# Altium schematic sheet sensors.SchDoc(sensors)
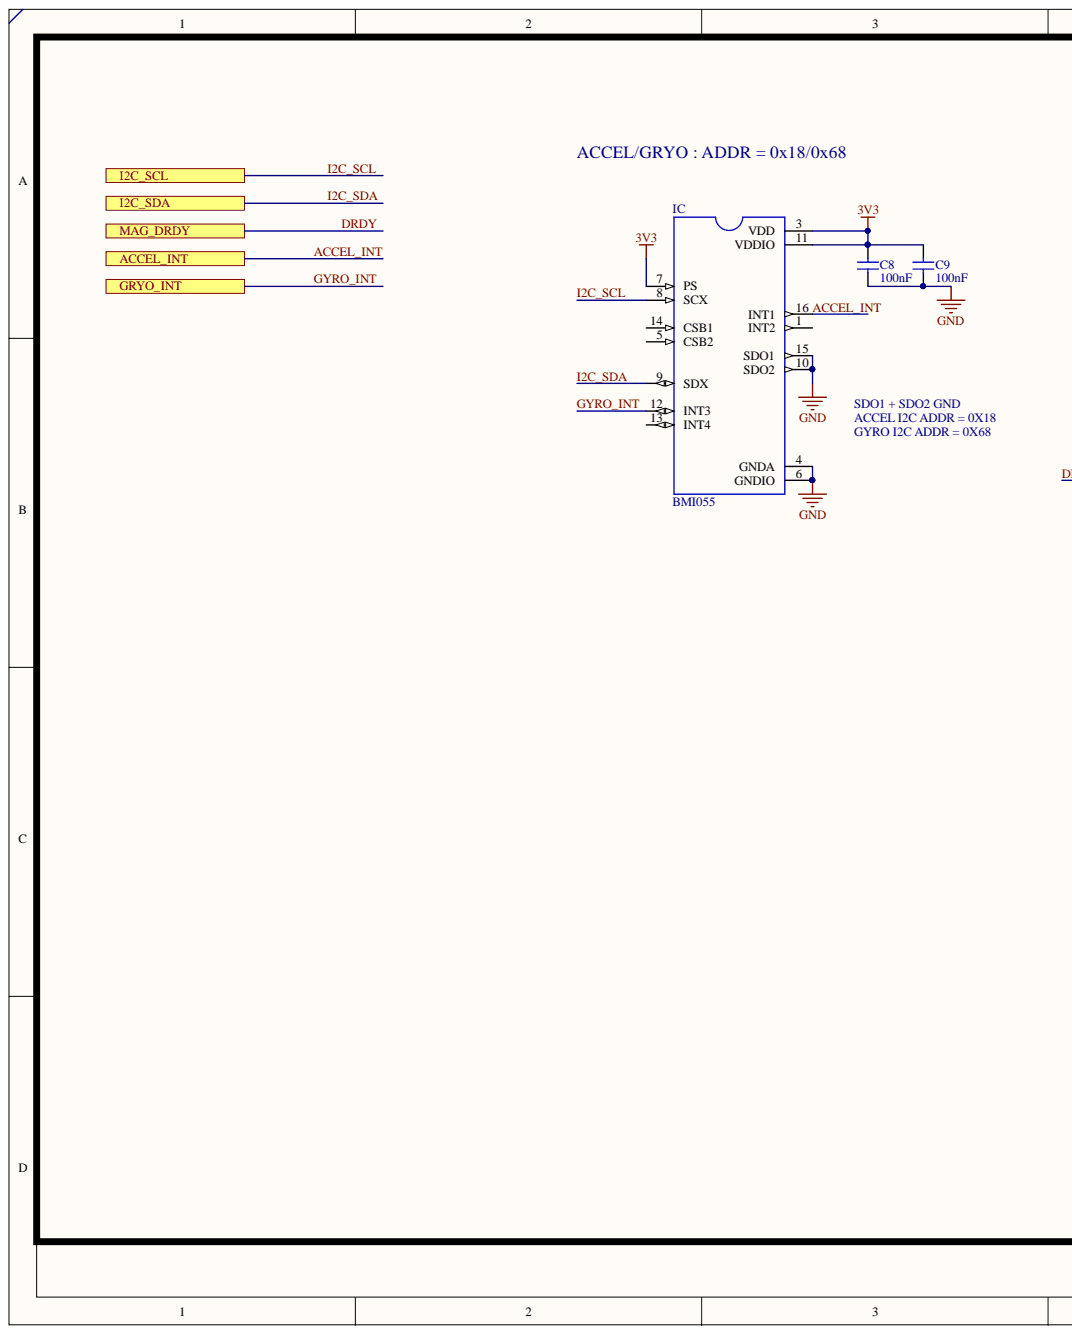
[diagram p0: sensors.SchDoc, left]
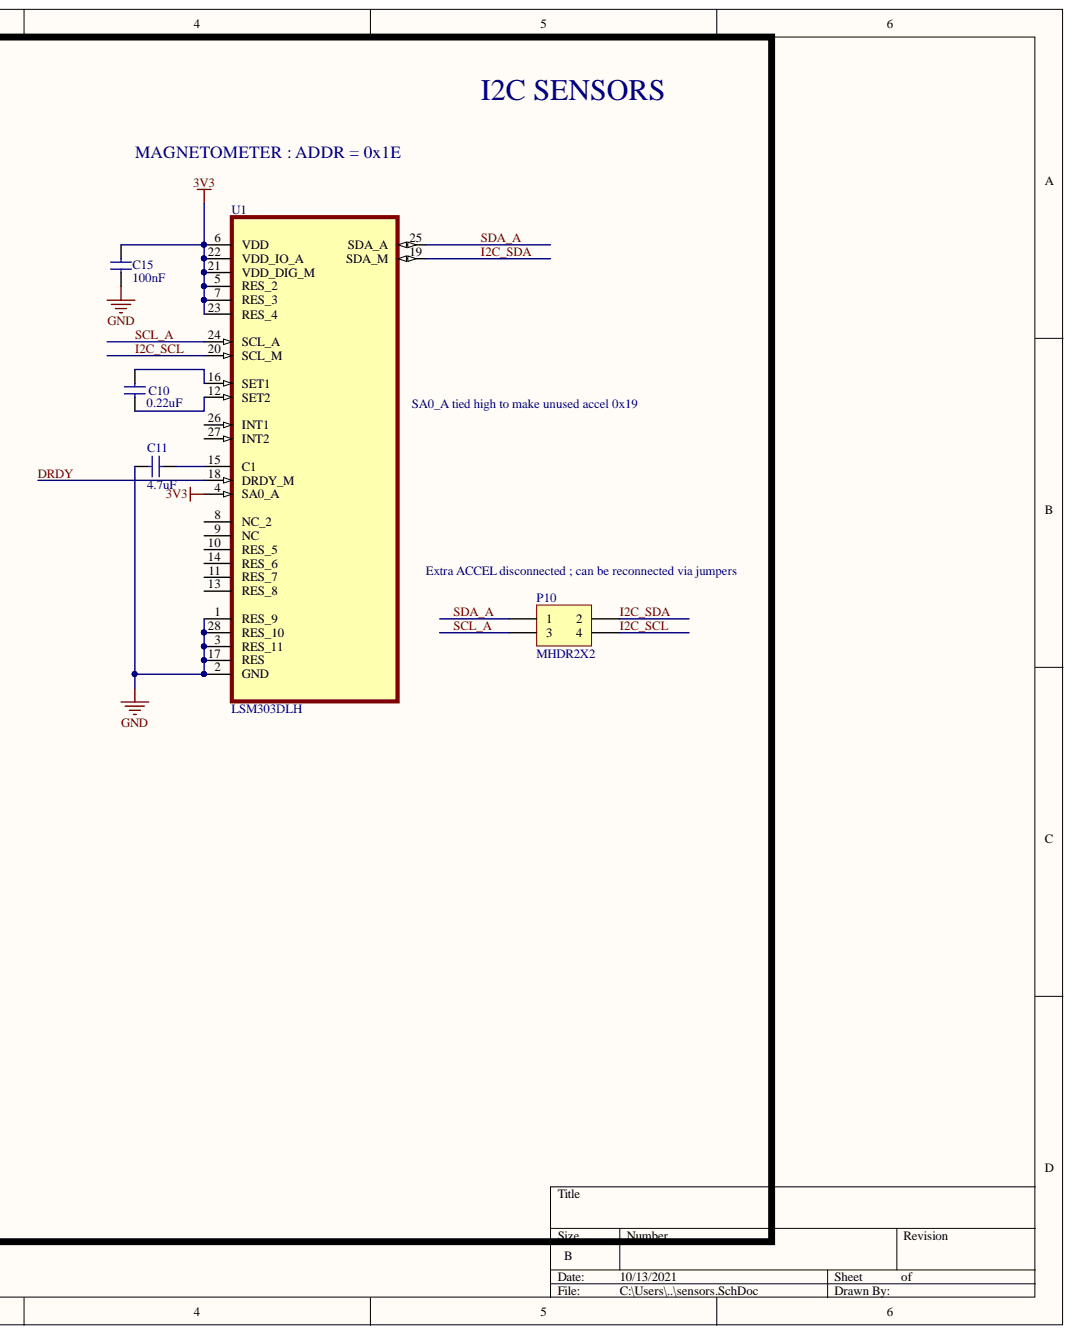
[diagram p1: sensors.SchDoc, right]

## Parts
C8  value 100nF  [CAPC0603]  (hidden comment: Cap)
C9  value 100nF  [CAPC0603]  (hidden comment: Cap)
C10  value 0.22uF  [CAPC0603]  (hidden comment: Cap)
C11  value 4.7uF  [CAPC0603]  (hidden comment: Cap)
C15  value 100nF  [CAPC0603]  (hidden comment: Cap)
IC  BMI055  [QFN50P300X450X100-16N]
P10  MHDR2X2  [MHDR2X2]
U1  LSM303DLH  [LGA28R55P7X7_500X500X100]

## Ports
ACCEL_INT, GRYO_INT, I2C_SCL, I2C_SDA, MAG_DRDY

## Nets
3V3: C8.1 C9.1 C15.1 IC.3(VDD) IC.7(PS) IC.11(VDDIO) U1.4(SA0_A) U1.5(RES_2) U1.6(VDD) U1.7(RES_3) U1.21(VDD_DIG_M) U1.22(VDD_IO_A) U1.23(RES_4)
GND: C8.2 C9.2 C11.1 C15.2 IC.4(GNDA) IC.6(GNDIO) IC.10(SDO2) IC.15(SDO1) U1.1(RES_9) U1.2(GND) U1.3(RES_11) U1.17(RES) U1.28(RES_10)
ACCEL_INT: IC.16(INT1) ; port ACCEL_INT
DRDY: U1.18(DRDY_M) ; port MAG_DRDY
GYRO_INT: IC.12(INT3) ; port GRYO_INT
I2C_SCL: IC.8(SCX) P10.4 U1.20(SCL_M) ; port I2C_SCL
I2C_SDA: IC.9(SDX) P10.2 U1.19(SDA_M) ; port I2C_SDA
SCL_A: P10.3 U1.24(SCL_A)
SDA_A: P10.1 U1.25(SDA_A)
NetC10_1: C10.1 U1.16(SET1)
NetC10_2: C10.2 U1.12(SET2)
NetC11_2: C11.2 U1.15(C1)

Not connected: IC 1(INT2) 5(CSB2) 13(INT4) 14(CSB1); U1 8(NC_2) 9(NC) 10(RES_5) 11(RES_7) 13(RES_8) 14(RES_6) 26(INT1) 27(INT2)

## Library data of the parts
IC = BMI055: Accelerometer, Gyroscope, 6 Axis Sensor IÂ²C, SPI Output; MF: Bosch; SnapEDA_Link: https://www.snapeda.com/parts/BMI055/Bosch%20Sensortec/view-part/48228/?ref=snap; Package: LGA-16 Bosch; MP: BMI055; Digi-Key_Purchase_URL: https://www.digikey.com.cn/product-detail/zh/bosch-sensortec/BMI055/828-1043-1-ND/4196679?utm_source=snapeda&utm_medium=aggregator&utm_campaign=symbol; Digi-Key_Part_Number: 828-1043-1-ND; Component Kind: Standard; Library Name: BMI055_long.IntLib
P10 = MHDR2X2: Header, 2-Pin, Dual row; Signal Integrity: Connector; Library Name: Miscellaneous Connectors.IntLib; LatestRevisionNote: Re-released for DXP Platform.; LatestRevisionDate: 17-Jul-2002; Component Kind: Standard; Publisher: Altium Limited
U1 = LSM303DLH: Accelerometer, Magnetometer, 6 Axis Sensor IÂ²C Output; MF: STMicroelectronics; SnapEDA_Link: https://www.snapeda.com/parts/LSM303DLH/STMicroelectronics/view-part/499714/?ref=snap; Price: None; Package: LGA-28 STMicroelectronics; MP: LSM303DLH; Library Name: LSM303DLH.IntLib; Component Kind: Standard; Check_prices: https://www.snapeda.com/parts/LSM303DLH/STMicroelectronics/view-part/499714/?ref=eda; Availability: In Stock
C8-C11 C15 = Cap: Supplier Part Number 1: 1276-1000-1-ND; Supplier 1: Digi-Key; Library Name: Passives.SchLib; Component Kind: Standard
